# Altium schematic sheet Power.SchDoc(Power)
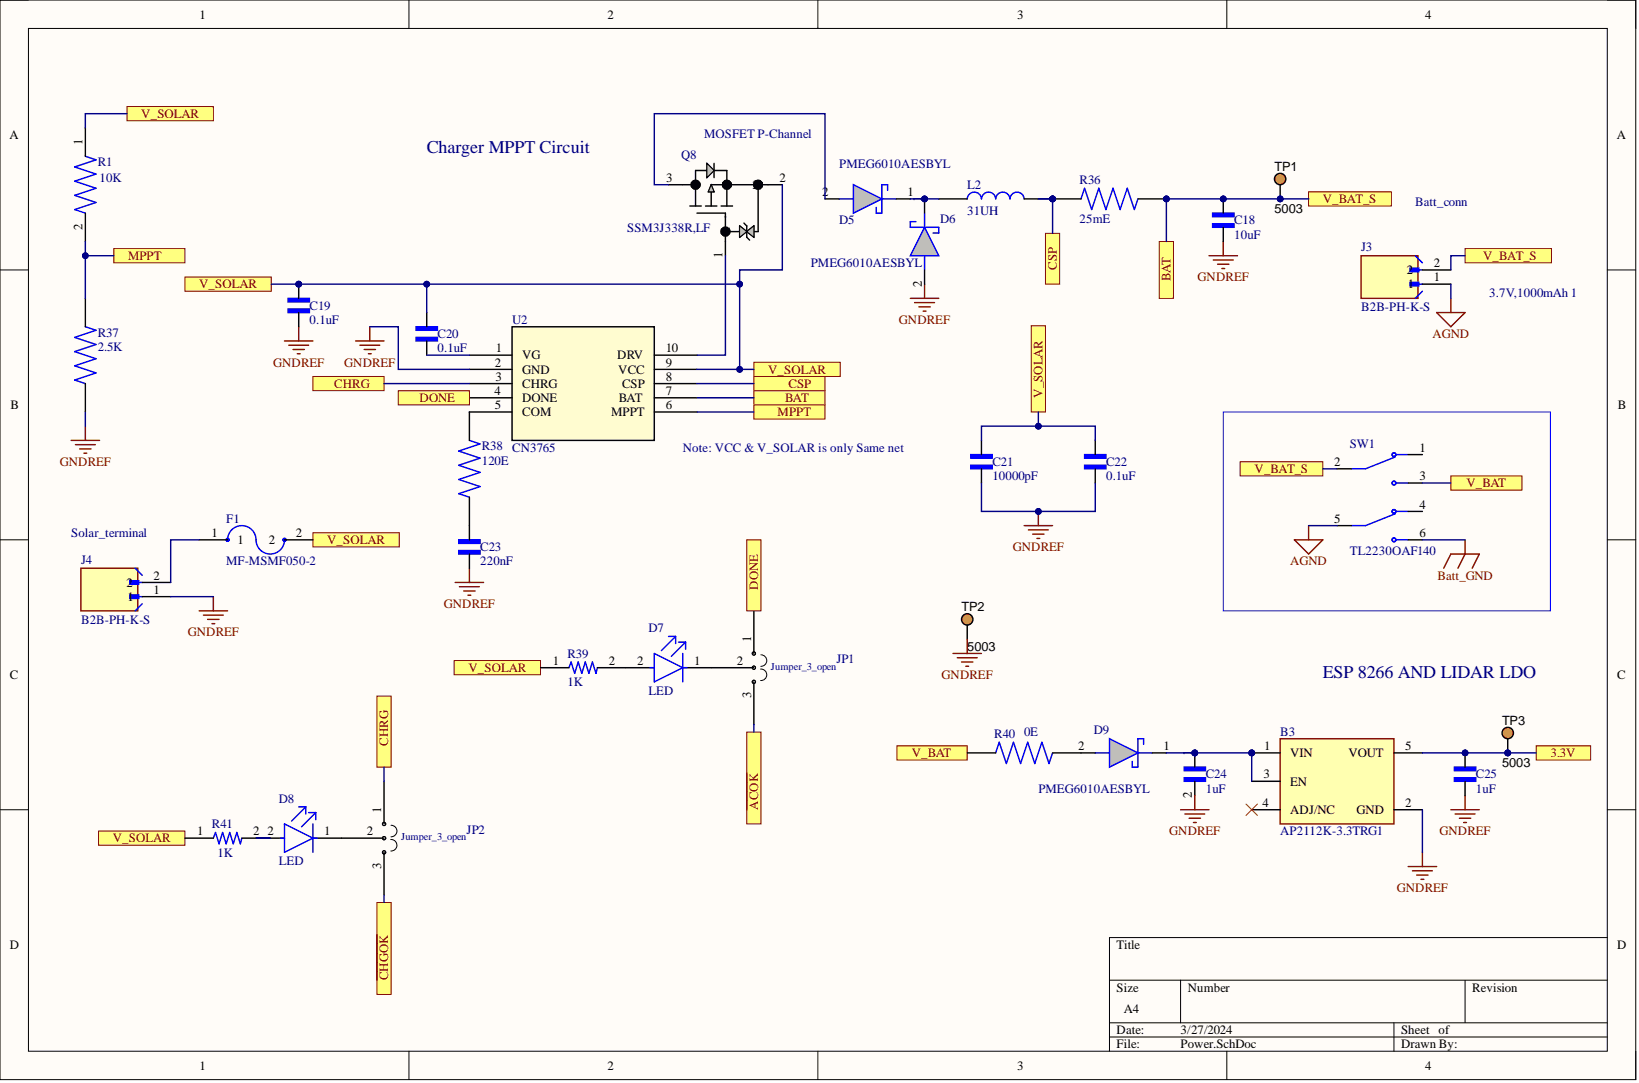
[diagram p0: Power.SchDoc]

## Parts
B3  AP2112K-3.3TRG1
C18  10uF
C19  0.1uF
C20  0.1uF
C21  10000pF
C22  0.1uF
C23  220nF
C24  1uF
C25  1uF
D5  PMEG6010AESBYL
D6  PMEG6010AESBYL
D7  LED
D8  LED
D9  PMEG6010AESBYL
F1  MF-MSMF050-2
J3  B2B-PH-K-S
J4  B2B-PH-K-S
JP1  (no value)
JP2  (no value)
L2  31UH
Q8  SSM3J338R,LF
R1  10K
R36  25mE
R37  2.5K
R38  120E
R39  1K
R40  0E
R41  1K
SW1  TL2230OAF140
TP1  5003
TP2  5003
TP3  5003
U2  CN3765

## Ports
3.3V, BAT, CHRG, CSP, DONE, MPPT, V_BAT, V_BAT_S, V_SOLAR, ~{ACOK}, ~{CHGOK}

## Nets
AGND: J3.1 SW1.5
Batt_GND: SW1.6
GNDREF: B3.2(GND) C18.1 C19.1 C21.1 C22.1 C23.1 C24.2 C25.2 D6.2 J4.1 R37.1 TP2.1 U2.2(GND)
3.3V: B3.5(VOUT) C25.1 TP3.1 ; port 3.3V
~{ACOK}: JP1.3 ; port ~{ACOK}
BAT: C18.2 J3.2 R36.2 SW1.2 TP1.1 U2.7(BAT) ; port BAT, port V_BAT_S
~{CHGOK}: JP2.3 ; port ~{CHGOK}
CHRG: JP2.1 U2.3(CHRG) ; port CHRG
CSP: L2.2 R36.1 U2.8(CSP) ; port CSP
DONE: JP1.1 U2.4(DONE) ; port DONE
MPPT: R1.2 R37.2 U2.6(MPPT) ; port MPPT
V_BAT: R40.1 SW1.3 ; port V_BAT
V_SOLAR: C19.2 C20.2 C21.2 C22.2 F1.2 Q8.2 R1.1 R39.1 R41.1 U2.9(VCC) ; port V_SOLAR
NetB3_1: B3.1(VIN) B3.3(EN) C24.1 D9.1
NetC20_1: C20.1 U2.1(VG)
NetC23_2: C23.2 R38.1
NetD5_1: D5.1 D6.1 L2.1
NetD5_2: D5.2 Q8.3
NetD7_1: D7.1 JP1.2
NetD7_2: D7.2 R39.2
NetD8_1: D8.1 JP2.2
NetD8_2: D8.2 R41.2
NetD9_2: D9.2 R40.2
NetF1_1: F1.1 J4.2
NetQ8_1: Q8.1 U2.10(DRV)
NetR38_2: R38.2 U2.5(COM)

Not connected: B3 4(ADJ/NC); SW1 1 4

## Library data of the parts
B3 = AP2112K-3.3TRG1: 600mA 3.3V LDO Regulator Enable SOT23-5 DiodesZetex AP2112K-3.3TRG1, LDO Voltage Regulator, 3.3 V +/-1.5%, 2.5  6 Vin, 5-Pin SOT-23; Manufacturer_Name: Diodes Incorporated; Geometry.Height: 1.3mm; Arrow Part Number: AP2112K-3.3TRG1; Arrow Price/Stock: https://www.arrow.com/en/products/ap2112k-3.3trg1/diodes-incorporated?region=nac; Component Kind: Standard; Datasheet Link: http://uk.rs-online.com/web/p/products/8283509; Library Name: AP2112K-3.3TRG1.SchLib; Manufacturer_Part_Number: AP2112K-3.3TRG1; Mouser Part Number: 621-AP2112K-3.3TRG1; Mouser Price/Stock: https://www.mouser.co.uk/ProductDetail/Diodes-Incorporated/AP2112K-3.3TRG1?qs=x6A8l6qLYDDPYHosCdzh%2FA%3D%3D
C18 = GRM188C81C106MA73J: Cap Ceramic 10uF 16V X6S 20% Pad SMD 0603 105Â°C T/R; MF: {'manufacturer': u'Murata'}; Availability: Unavailable; Component Kind: Standard; MP: GRM188C81C106MA73J; Package: None; Price: None
C19 C20 C22 = CL10B104KO8NNNC: MF: {'manufacturer': u'Samsung Electro-Mechanics'}; Availability: Unavailable; Component Kind: Standard; MP: CL10B104KO8NNNC; Package: None; Price: None
C21 = GCM188R72A103KA37D: .01UF 100V 10% 0603; MF: {'manufacturer': u'Murata'}; Availability: Unavailable; Component Kind: Standard; MP: GCM188R72A103KA37D; Package: None; Price: None
C23 = GRM188R71E224KA88D: SMD Capacitor X7R(EIA) with Capacitance: 0.22uF Tol. 10%. Rated Voltage: 25Vdc <a href="https://pricing.snapeda.com/parts/GRM188R71E224KA88D/Murata%20Electronics/view-part?ref=eda">Check availability</a>; MF: {'manufacturer': u'Murata Electronics'}; Availability: Unavailable; Component Kind: Standard; MP: GRM188R71E224KA88D; Package: None; Price: None
C24 C25 = CC0603KRX5R8BB105: CERAMIC CAPACITOR, 1UF, 25V, X5R, 10%, 0603, FULL REEL; MF: {'manufacturer': u'Yageo'}; Availability: Unavailable; Component Kind: Standard; MP: CC0603KRX5R8BB105; Package: None; Price: None
D5 D6 D9 = PMEG6010AESBYL: Schottky Diodes & Rectifiers SCHOTTKY DIODE; Manufacturer_Name: Nexperia; Geometry.Height: 0.3mm; Arrow Part Number: PMEG6010AESBYL; Arrow Price/Stock: https://www.arrow.com/en/products/pmeg6010aesbyl/nexperia?region=nac; Component Kind: Standard; Datasheet Link: https://assets.nexperia.com/documents/data-sheet/PMEG6010AESB.pdf; Library Name: PMEG6010AESBYL.SchLib; Manufacturer_Part_Number: PMEG6010AESBYL; Mouser Part Number: 771-PMEG6010AESBYL; Mouser Price/Stock: https://www.mouser.co.uk/ProductDetail/Nexperia/PMEG6010AESBYL?qs=gH1BdSMZm8V0nKx5XmgkvA%3D%3D
D7 D8 JP1 JP2 = Component_1: Component Kind: Standard
  D7 D8: Library Name: LED.SchLib
  JP1 JP2: Library Name: Jumper_3_open..SchLib
F1 = MF-MSMF050-2: PTC Resettable Fuse 0.5A(hold) 1A(trip) 15V 100A 0.8W 0.15s 0.15Ohm SMD Solder Pad 1812 T/R; MF: {'manufacturer': u'Bourns'}; Availability: Unavailable; Component Kind: Standard; MP: MF-MSMF050-2; Package: None; Price: None
J3 J4 = B2B-PH-K-S: MF: {'manufacturer': u'JST Sales America Inc.'}; Availability: Unavailable; Component Kind: Standard; MP: B2B-PH-K-S; Package: None; Price: None
L2 = 7447706330: Fixed Inductors WE-PD SMT Power Inductor Size 1260; L = 33 H 20%; IR = 3 A; Isat = 4,2 A; RDC = 60 m; Manufacturer_Name: Wurth Elektronik; Geometry.Height: 6mm; Component Kind: Standard; Datasheet Link: https://componentsearchengine.com/Datasheets/1/7447706330.pdf; Library Name: 7447706330.SchLib; Manufacturer_Part_Number: 7447706330; Mouser Part Number: 710-7447706330; Mouser Price/Stock: https://www.mouser.co.uk/ProductDetail/Wurth-Elektronik/7447706330?qs=GBLSl2Akirts%2F0eLw6o4jA%3D%3D
Q8 = SSM3J338R,LF: No Description Available; Mfr_Name: Toshiba Electronic Devices & Storage Corp.; Component Kind: Standard; Library Name: SSM3J338R,LF.SchLib; RefDes: Refdes; Type: Type
R1 = RC0603FR-0710KL: YAGEO RC0603FR-0710KL / RESISTOR 10K 1/10W 1% 0603 YAG <a href="https://pricing.snapeda.com/parts/RC0603FR-0710KL/Yageo/view-part?ref=eda">Check availability</a>; MF: {'manufacturer': u'Yageo'}; Availability: Unavailable; Component Kind: Standard; MP: RC0603FR-0710KL; Package: None; Price: None
R36 = RL1206FR-070R025L: Res Thick Film 1206 0.025 Ohm 1% 0.25W(1/4W) Â±1200ppm/Â°C Epoxy Pad SMD Automotive T/R; MF: {'manufacturer': u'Yageo'}; Availability: Unavailable; Component Kind: Standard; MP: RL1206FR-070R025L; Package: None; Price: None
R37 = RNCF0603BTE2K50: MF: {'manufacturer': u'Stackpole Electronics Inc'}; Availability: Unavailable; Component Kind: Standard; MP: RNCF0603BTE2K50; Package: None; Price: None
R38 = RMCF0603FT120R: MF: {'manufacturer': u'Stackpole Electronics Inc'}; Availability: Unavailable; Component Kind: Standard; MP: RMCF0603FT120R; Package: None; Price: None
R39 R41 = RC0603FR-071KL: RES SMT 1K 5% 0603 T/R PICK#150742; MF: {'manufacturer': u'Yageo'}; Availability: Unavailable; Component Kind: Standard; MP: RC0603FR-071KL; Package: None; Price: None
R40 = RMCF0603ZT0R00: MF: {'manufacturer': u'Stackpole Electronics'}; Availability: Unavailable; Component Kind: Standard; MP: RMCF0603ZT0R00; Package: None; Price: None
SW1 = TL2230OAF140: MF: {'manufacturer': u'E-Switch'}; Availability: Unavailable; Component Kind: Standard; MP: TL2230OAF140; Package: None; Price: None
TP1-TP3 = CMP-002-00037-2: Test Point, Orange, Height 4.6 mm, Tail Length 3 mm, 1-Pin THD, RoHS; Manufacturer: Keystone; Case/Package: 5003; Colour: Orange; Component Kind: Standard; Connector Type: TestPoint; Contact Material: Bronze; Contact Plating: Tin; Datasheet URL: http://keyelco.com/userAssets/file/M65p56.pdf; Datasheet Version: Jun-15; Diameter: 2.54mm; Manufacturer URL: http://www.keyelco.com/; Mounting Technology: TH; Package Description: 1-Pin Through Hole Device, Dia 2.54 mm; Package Quantity: 10; Package Reference: 5003; Packaging: Bulk; Pins: 1; RoHS Compliant: True; Library Name: Srushty Global Solutions Pvt Ltd
U2 = CN3765: No Description Available; Mfr_Name: Consonance; Component Kind: Standard; Library Name: CN3765.SchLib; Manufacturer_Part_Number: CN3765; RefDes: RefDes; Type: DEV; Copyright: Copyright (C) 2024 Ultra Librarian. All rights reserved.
